# Altium schematic sheet schematic.SchDoc(schematic)
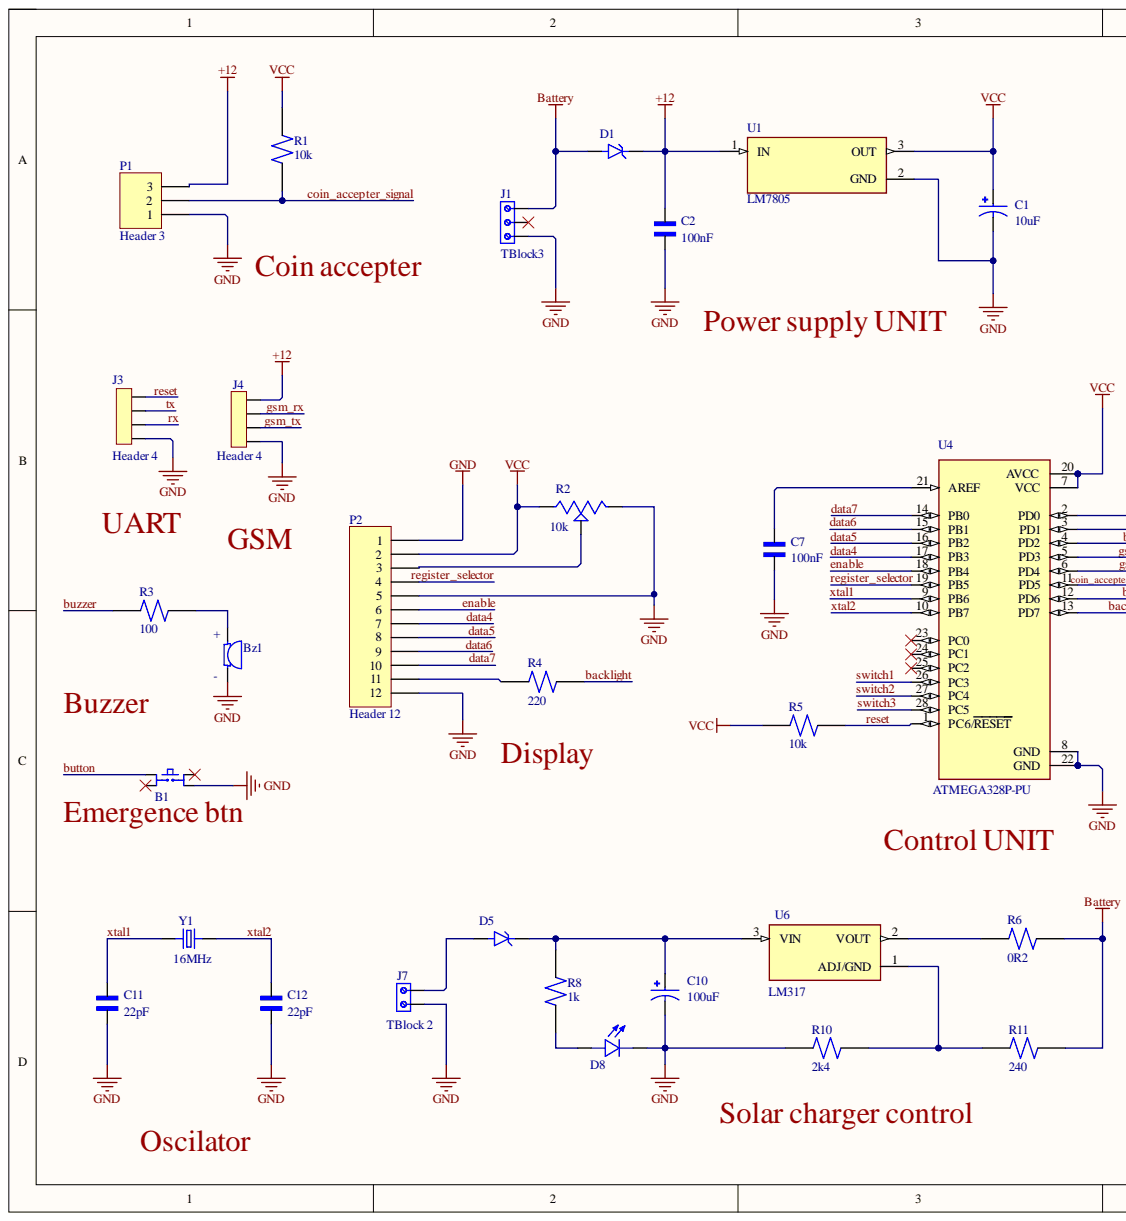
[diagram p0: schematic.SchDoc, left]
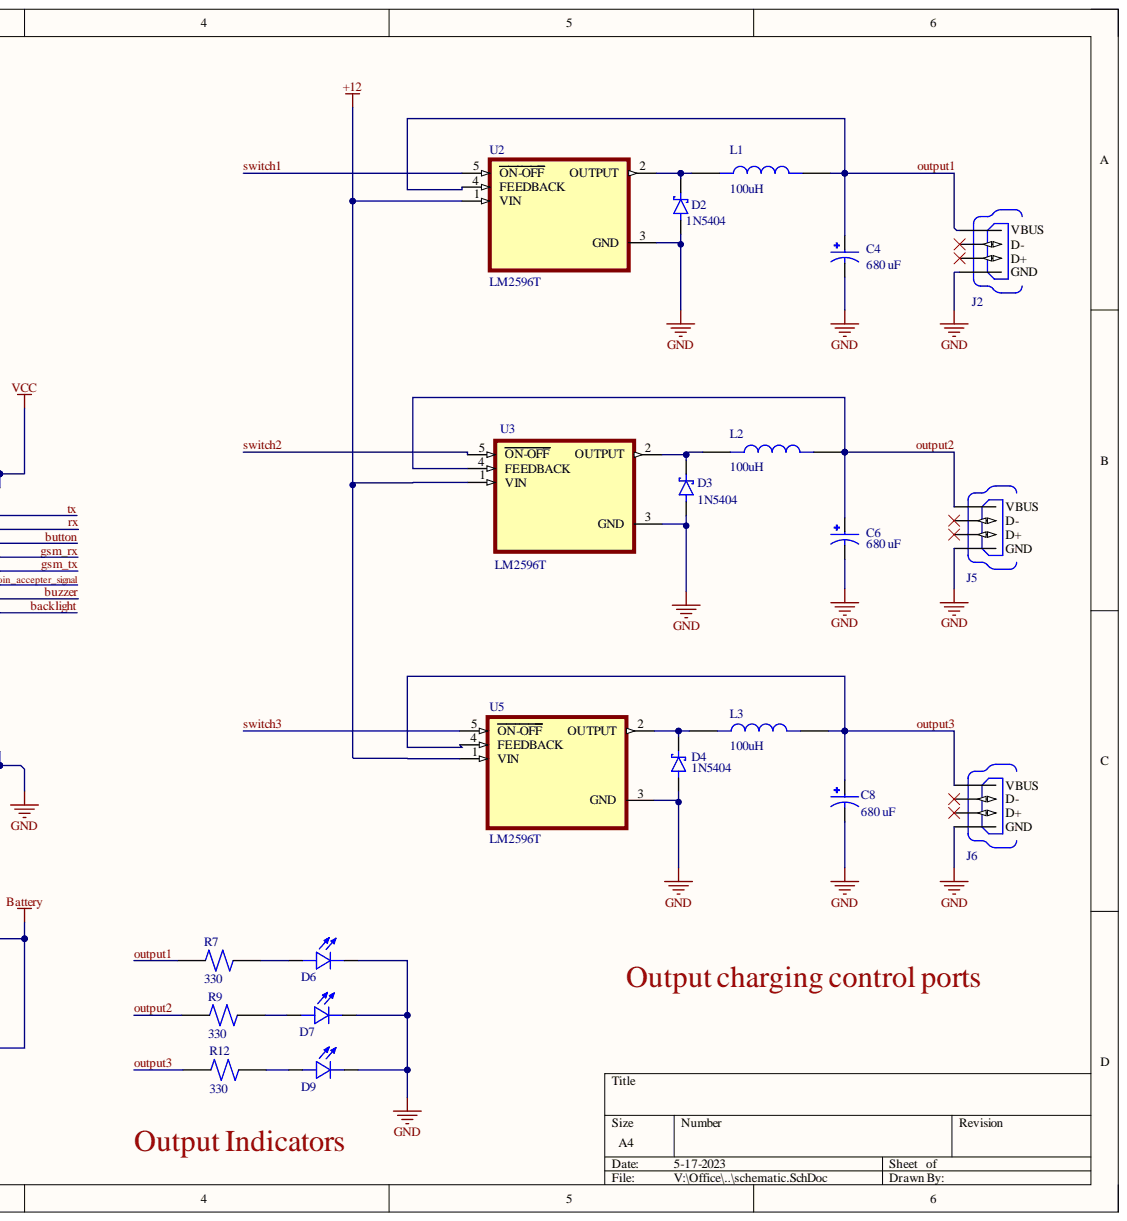
[diagram p1: schematic.SchDoc, right]

## Parts
B1  Push button  [SW_TS02-66-95-BK-260-LCR-D]  (value not drawn)
Bz1  AI-1223-TWT-12V-R  [XDCR_AI-1223-TWT-12V-R]  (value not drawn)
C1  10uF  [CAPPRD500W50D500H1100]
C2  100nF  [CAPRB500W50L450T300H550]
C4  680 uF  [CAPPRD500W50D500H1100]
C6  680 uF  [CAPPRD500W50D500H1100]
C7  100nF  [CAPRB500W50L450T300H550]
C8  680 uF  [CAPPRD500W50D500H1100]
C10  100uF  [CAPPRD500W50D500H1100]
C11  22pF  [CAPRB500W50L450T300H550]
C12  22pF  [CAPRB500W50L450T300H550]
D1  1N4745A  [DIOAD1060W70L435D230]  (value not drawn)
D2  1N5404  [DIOAD1990W125L900D530]
D3  1N5404  [DIOAD1990W125L900D530]
D4  1N5404  [DIOAD1990W125L900D530]
D5  1N4745A  [DIOAD1060W70L435D230]  (value not drawn)
D6  L08R5000Q1  [LEDRD254W57D500H1070]  (value not drawn)
D7  L08R5000Q1  [LEDRD254W57D500H1070]  (value not drawn)
D8  L08R5000Q1  [LEDRD254W57D500H1070]  (value not drawn)
D9  L08R5000Q1  [LEDRD254W57D500H1070]  (value not drawn)
J1  TBlock3  [691214110003]
J2  USB-A1HSW6  [OST_USB-A1HSW6]  (value not drawn)
J3  Header 4  [SULLINS_PPTC041LFBN-RC]
J4  Header 4  [SULLINS_PPTC041LFBN-RC]
J5  USB-A1HSW6  [OST_USB-A1HSW6]  (value not drawn)
J6  USB-A1HSW6  [OST_USB-A1HSW6]  (value not drawn)
J7  TBlock 2  [691111710002]
L1  100uH  [IND_FIRCH-3]
L2  100uH  [IND_FIRCH-3]
L3  100uH  [IND_FIRCH-3]
P1  Header 3  [HDR1X3]
P2  Header 12  [HDR1X12]
R1  10k  [RESAD1100W55L680D260]
R2  10k  [TRIM_3306F-1-105]
R3  100  [RESAD1100W55L680D260]
R4  220  [RESAD1100W55L680D260]
R5  10k  [RESAD1100W55L680D260]
R6  0R2  [RESAD1100W55L680D260]
R7  330  [RESAD1100W55L680D260]
R8  1k  [RESAD1100W55L680D260]
R9  330  [RESAD1100W55L680D260]
R10  2k4  [RESAD1100W55L680D260]
R11  240  [RESAD1100W55L680D260]
R12  330  [RESAD1100W55L680D260]
U1  LM7805  [TO254P1054X470X1955-3]
U2  LM2596T  [TO170P1016X470X2092-5]
U3  LM2596T  [TO170P1016X470X2092-5]
U4  ATMEGA328P-PU  [DIP794W46P254L2967H457Q28B]
U5  LM2596T  [TO170P1016X470X2092-5]
U6  LM317  [TO255P1020X450X1968-3]
Y1  16MHz  [XTAL_ECS-160-20-4X]

## Nets
+12: C2.2 D1.C J4.1 P1.3 U1.1 U2.1(VIN) U3.1(VIN) U5.1(VIN)
Battery: D1.A J1.3 R6.2 R11.2
GND: B1.4 Bz1.N C1.N C2.1 C4.N C6.N C7.1 C8.N C10.N C11.1 C12.1 D2.A D3.A D4.A D6.C D7.C D8.C D9.C J1.1 J2.4 J3.4 J4.4 J5.4 J6.4 J7.1 P1.1 P2.1 P2.5 P2.12 R2.3 R10.1 U1.2 U2.3(GND) U3.3(GND) U4.8(GND) U4.22(GND) U5.3(GND)
VCC: C1.P P2.2 R1.1 R2.1 R5.2 U1.3 U4.7(VCC) U4.20(AVCC)
backlight: R4.2 U4.13(PD7)
button: B1.1 U4.4(PD2)
buzzer: R3.1 U4.12(PD6)
coin_accepter_signal: P1.2 R1.2 U4.11(PD5)
data4: P2.7 U4.17(PB3)
data5: P2.8 U4.16(PB2)
data6: P2.9 U4.15(PB1)
data7: P2.10 U4.14(PB0)
enable: P2.6 U4.18(PB4)
gsm_rx: J4.2 U4.5(PD3)
gsm_tx: J4.3 U4.6(PD4)
output1: C4.P J2.1 L1.2 R7.2 U2.4(FEEDBACK)
output2: C6.P J5.1 L2.2 R9.2 U3.4(FEEDBACK)
output3: C8.P J6.1 L3.2 R12.2 U5.4(FEEDBACK)
register_selector: P2.4 U4.19(PB5)
reset: J3.1 R5.1 U4.1(PC6/RESET)
rx: J3.3 U4.3(PD1)
switch1: U2.5(ON-OFF) U4.26(PC3)
switch2: U3.5(ON-OFF) U4.27(PC4)
switch3: U4.28(PC5) U5.5(ON-OFF)
tx: J3.2 U4.2(PD0)
xtal1: C11.2 U4.9(PB6) Y1.1
xtal2: C12.2 U4.10(PB7) Y1.2
NetBz1_P: Bz1.P R3.2
NetC7_2: C7.2 U4.21(AREF)
NetC10_P: C10.P D5.C R8.2 U6.3
NetD2_C: D2.C L1.1 U2.2(OUTPUT)
NetD3_C: D3.C L2.1 U3.2(OUTPUT)
NetD4_C: D4.C L3.1 U5.2(OUTPUT)
NetD5_A: D5.A J7.2
NetD6_A: D6.A R7.1
NetD7_A: D7.A R9.1
NetD8_A: D8.A R8.1
NetD9_A: D9.A R12.1
NetP2_3: P2.3 R2.2
NetP2_11: P2.11 R4.1
NetR6_1: R6.1 U6.2
NetR10_2: R10.2 R11.1 U6.1

Not connected: B1 2 3; J1 2; J2 2(D-) 3; J5 2 3; J6 2 3; U4 23(PC0) 24(PC1) 25(PC2)

## Library data of the parts
Bz1 = Buzzer: ELECTRO-MECHANICAL AUDIO INDICATOR; MF: PUI Audio,; Availability: In Stock; Component Kind: Standard; MP: AI-1223-TWT-12V-R; Package: 2225 PUI Audio; Price: None; Purchase-URL: https://pricing.snapeda.com/search/part/AI-1223-TWT-12V-R/?ref=eda; Library Name: Installed library.IntLib
C1 C4 C6 C8 C10 = Electrolytic capacitor: FS-A; MF: {'manufacturer': u'Panasonic'}; MP: EEUFS0J221B; Availability: Unavailable; Component Kind: Standard; Package: None; Price: None; Library Name: Installed library.IntLib
C2 C7 C11 C12 = Ceramic capacitor: 2200 pF Â±10% 250V Ceramic Capacitor X7R Radial; MF: TDK; MP: FG24X7R2E222KNT06; Check_prices: https://www.snapeda.com/parts/FG24X7R2E222KNT06/TDK/view-part/?ref=eda; Component Kind: Standard; Digi-Key_Part_Number: 445-173386-1-ND; Package: Radial TDK; Purchase-URL: https://pricing.snapeda.com/search/part/FG24X7R2E222KNT06/?ref=eda; SnapEDA_Link: https://www.snapeda.com/parts/FG24X7R2E222KNT06/TDK/view-part/?ref=snap; Library Name: Installed library.IntLib
D1 D5 = Zenner diode: Zener Diode 16V 1W Â±5% Through Hole DO-204AL (DO-41); MF: ON Semiconductor; Availability: In Stock; Check_prices: https://www.snapeda.com/parts/1N4745A/Onsemi/view-part/?ref=eda; Component Kind: Standard; MP: 1N4745A; Package: DO-41-2 ON Semiconductor; Price: None; Purchase-URL: https://pricing.snapeda.com/search/part/1N4745A/?ref=eda; SnapEDA_Link: https://www.snapeda.com/parts/1N4745A/Onsemi/view-part/?ref=snap; Library Name: Installed library.IntLib
D2-D4 = Schrotic diode: Diode Standard 400 V 3A Through Hole DO-201AD; MF: ON Semiconductor; Availability: In Stock; Check_prices: https://www.snapeda.com/parts/1N5404/Onsemi/view-part/?ref=eda; Component Kind: Standard; MP: 1N5404; Package: AXIAL LEAD-2 ON Semiconductor; Price: None; Purchase-URL: https://pricing.snapeda.com/search/part/1N5404/?ref=eda; SnapEDA_Link: https://www.snapeda.com/parts/1N5404/Onsemi/view-part/?ref=snap; Library Name: Installed library.IntLib
D6-D9 = LED: LED, Orange, Through Hole, T-1 3/4 (5mm), 30 mA, 2.1 V, 635 nm; MF: {'manufacturer': u'LED Technology'}; Availability: Unavailable; Component Kind: Standard; MP: L08R5000Q1; Package: None; Price: None; Library Name: Installed library.IntLib
J1 = Terminal blocks - 3Pins (Small): 3 Position Wire to Board Terminal Block Horizontal with Board 0.138 (3.50mm) Through Hole; MF: Wurth Elektronik; Availability: In Stock; Check_prices: https://www.snapeda.com/parts/691214110003/Wurth+Elektronik/view-part/?ref=eda; Component Kind: Standard; MP: 691214110003; Package: None; Price: None; Purchase-URL: https://pricing.snapeda.com/search/part/691214110003/?ref=eda; SnapEDA_Link: https://www.snapeda.com/parts/691214110003/Wurth+Elektronik/view-part/?ref=snap; Library Name: Installed library.IntLib
J2 J5 J6 = USB-A: USB - A Receptacle Connector 4 Position Through Hole, Right Angle; Availability: Unavailable; Component Kind: Standard; MP: USB-A1HSW6; Package: None; Price: None; Library Name: Installed library.IntLib
J3 J4 = Female header - 4Pins: 4 Position Header Connector Through Hole; MF: Sullins Connector; MP: PPTC041LFBN-RC; Availability: Warning; Check_prices: https://www.snapeda.com/parts/PPTC041LFBN-RC/Sullins%20Connector%20Solutions/view-part/285917/?ref=eda; Component Kind: Standard; Package: None; Price: None; Purchase-URL: https://pricing.snapeda.com/search/part/PPTC041LFBN-RC/?ref=eda; SnapEDA_Link: https://www.snapeda.com/parts/PPTC041LFBN-RC/Sullins%20Connector%20Solutions/view-part/285917/?ref=snap; Library Name: Installed library.IntLib
J7 = Terminal Block - 2Pins: 2 Position Wire to Board Terminal Block Horizontal with Board 0.197 _5.00mm_ Through Hole; MF: Wurth Electronics; Availability: Unavailable; Component Kind: Standard; MP: 691111710002; Package: None; Price: None; SnapEDA_Link: https://www.snapeda.com/parts/691111710002/Wurth%20Electronics%20Inc./view-part/1195092/?ref=snap; Library Name: Installed library.IntLib
L1-L3 = Inductor: INDUCTOR 3.60uH@8.1ADC ROD CORE/FIRCH-3 REV D; Availability: Unavailable; Component Kind: Standard; MP: FIRCH-3; Package: None; Price: None; Library Name: Installed library.IntLib
P1 = Header 3: Header, 3-Pin; Component Kind: Standard; LatestRevisionDate: 17-Jul-2002; LatestRevisionNote: Re-released for DXP Platform.; Library Name: Miscellaneous Connectors.IntLib; Signal Integrity: Connector; Publisher: Altium Limited
P2 = Header 12: Header, 12-Pin; Component Kind: Standard; LatestRevisionDate: 17-Jul-2002; LatestRevisionNote: Re-released for DXP Platform.; Library Name: Miscellaneous Connectors.IntLib; Signal Integrity: Connector; Publisher: Altium Limited
R1 R3-R12 = Resistor (0.25W): (MFR-25FBF52-10K) 10,2 kOhm bei 1% 0,25W, 1/4W Durchbohrlochwiderstand Axial Metal Film; MF: Yageo; Component Kind: Standard; Digi-Key_Part_Number: 10.2KXBK-ND; MP: MFR-25FBF52-10K; Package: Axial Yageo; Purchase-URL: https://pricing.snapeda.com/search/part/MFR-25FBF52-10K/?ref=eda; SnapEDA_Link: https://www.snapeda.com/parts/MFR-25FBF52-10K/Yageo/view-part/424333/?ref=snap; Library Name: Installed library.IntLib
R2 = Potentiometer1: (3306F-1-105) 1 MOhms 0.2W, 1/5W PC Pins Through Hole Trimmer Potentiometer Cermet 1 Turn Top Adjustment; MF: Bourns; Availability: Good; Check_prices: https://www.snapeda.com/parts/3306F-1-105/Bourns%20-%20J.W.%20Miller/view-part/862876/?ref=eda; Component Kind: Standard; MP: 3306F-1-105; Package: 3306F Bourns; Price: None; Purchase-URL: https://pricing.snapeda.com/search/part/3306F-1-105/?ref=eda; SnapEDA_Link: https://www.snapeda.com/parts/3306F-1-105/Bourns%20-%20J.W.%20Miller/view-part/862876/?ref=snap; Library Name: Installed library.IntLib
U1 = LM7805: MP: LM7805CT/NOPB; Availability: Unavailable; Component Kind: Standard; Package: None; Price: None; Library Name: Installed library.IntLib
U2 U3 U5 = LM2596T: MP: LM2596T-5.0; Availability: Unavailable; Component Kind: Standard; Package: None; Price: None; Library Name: Installed library.IntLib
U4 = ATMEGA328P-PU: ATmega Series 20 MHz 32 KB Flash 2 KB SRAM 8-Bit Microcontroller - DIP-28; Availability: Unavailable; Component Kind: Standard; MP: ATMEGA328P-PU; Package: None; Price: None; Library Name: Installed library.IntLib
U6 = LD1117V33: Availability: Unavailable; Component Kind: Standard; MP: LD1117V33; Package: None; Price: None; Library Name: Installed library.IntLib
Y1 = Crystal oscilator: CRYSTAL T/H; MF: {'manufacturer': u'ECS Inc.'}; MP: ECS-160-S-4; Availability: Unavailable; Component Kind: Standard; Package: None; Price: None; Library Name: Installed library.IntLib
B1 = Push button: Component Kind: Standard; Library Name: Installed library.IntLib
